# Altium schematic sheet Shield_sch.SchDoc
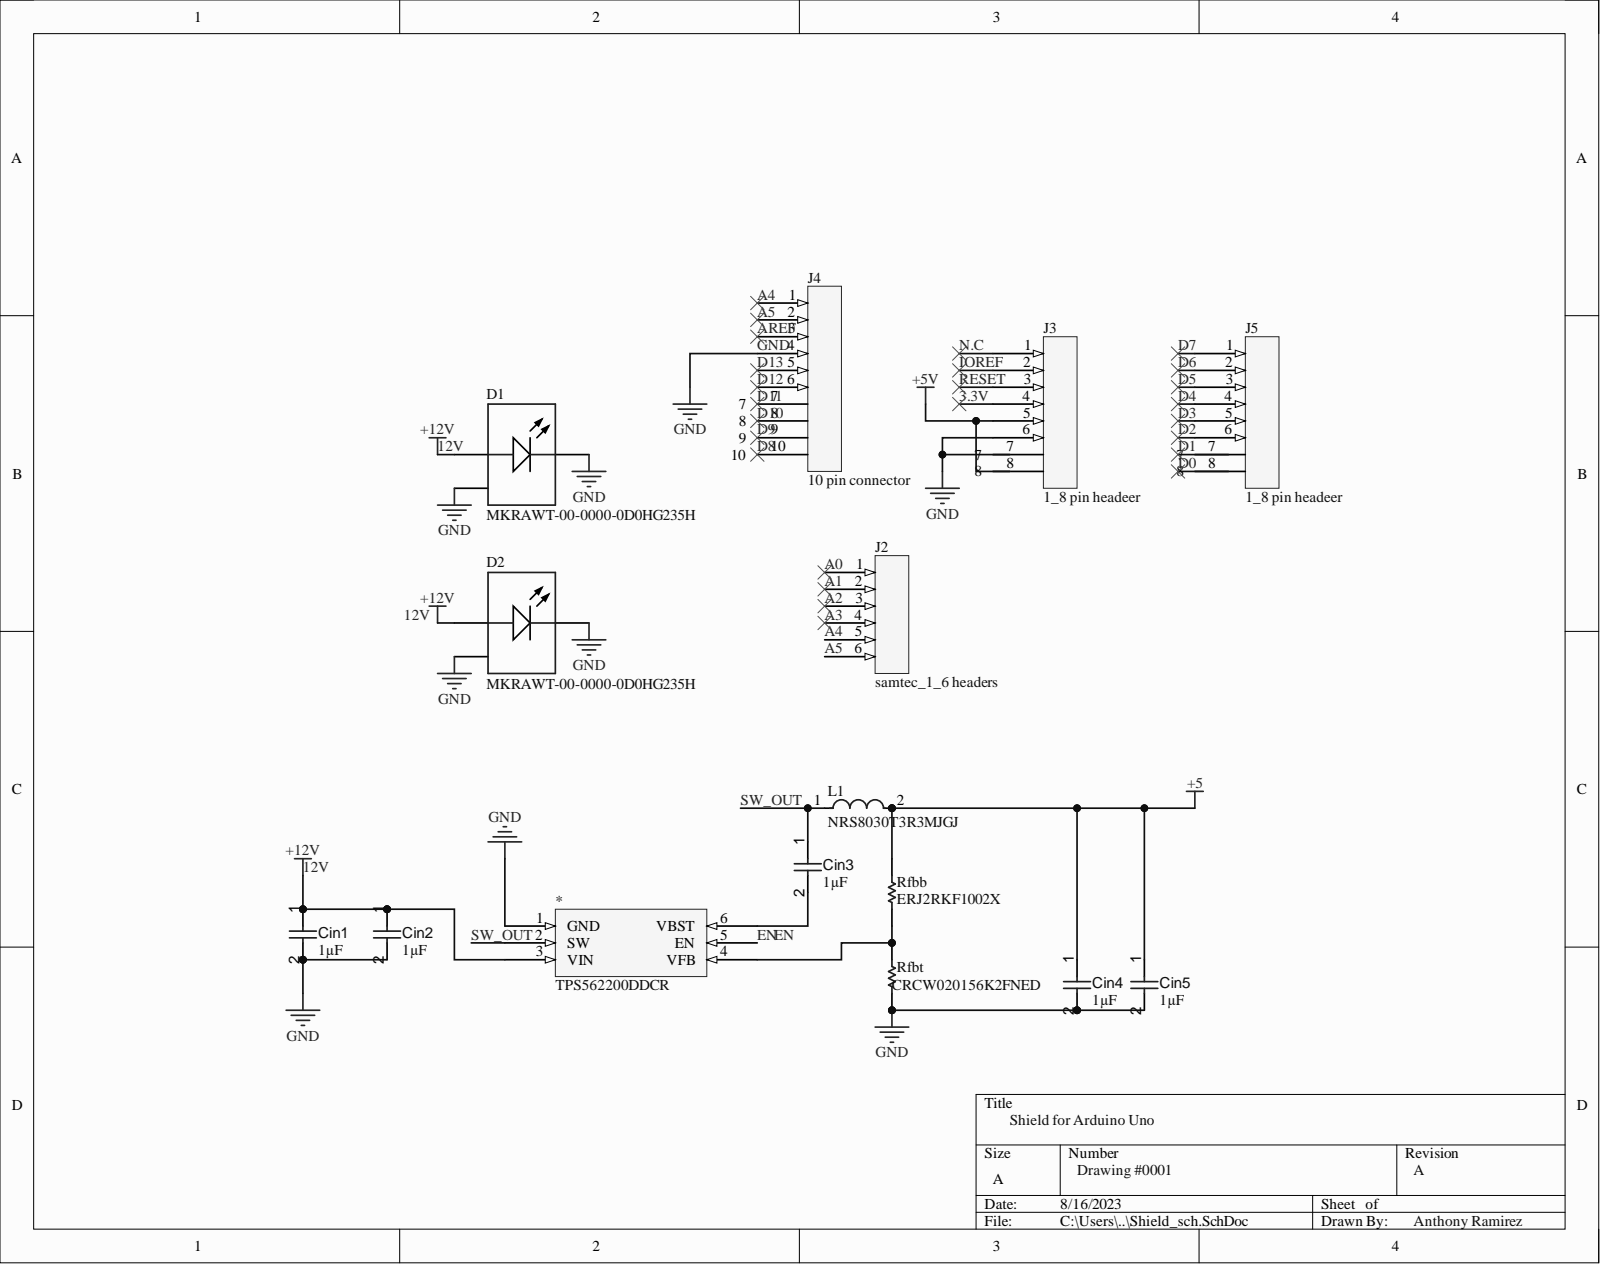
[diagram p0: Shield_sch.SchDoc]

## Parts
*  TPS562200DDCR  [SOT95P245X110-6N]
Cin1  value 1µF  [CAPC1608X90X40LL10T20]  (hidden comment: Capacitor 1 uF +/- 10% 25 V 0603)
Cin2  value 1µF  [CAPC1608X90X40LL10T20]  (hidden comment: Capacitor 1 uF +/- 10% 25 V 0603)
Cin3  value 1µF  [CAPC1608X90X40LL10T20]  (hidden comment: Capacitor 1 uF +/- 10% 25 V 0603)
Cin4  value 1µF  [CAPC1608X90X40LL10T20]  (hidden comment: Capacitor 1 uF +/- 10% 25 V 0603)
Cin5  value 1µF  [CAPC1608X90X40LL10T20]  (hidden comment: Capacitor 1 uF +/- 10% 25 V 0603)
D1  MKRAWT-00-0000-0D0HG235H  [CREE-MK-R-2_V]
D2  MKRAWT-00-0000-0D0HG235H  [CREE-MK-R-2_V]
J2  samtec_1_6 headers  [samtec_1_6 headers-Footprint-1]
J3  1_8 pin headeer  [1_8_Pin headers-Footprint-1]
J4  10 pin connector  [10 pin connector-Footprint-1]
J5  1_8 pin headeer  [1_8_Pin headers-Footprint-1]
L1  NRS8030T3R3MJGJ  [FP-NRS8030-MFG]
Rfbb  ERJ2RKF1002X  [RESC1005X40X25LL05T05]
Rfbt  CRCW020156K2FNED  [RESC0603X28X15NL05T05]

## Nets
+5: Cin4.1 Cin5.1 L1.2 Rfbb.1
+5V: J3.5
3.3V: J3.4
12V: *.3(VIN) Cin1.1 Cin2.1 D1.1 D2.1
A0: J2.1
A1: J2.2
A2: J2.3
A3: J2.4
A4: J2.5 J4.1
A5: J2.6 J4.2
AREF: J4.3
D2: J5.6
D3: J5.5
D4: J5.4
D5: J5.3
D6: J5.2
D7: J5.1
D12: J4.6
D13: J4.5
EN: *.5(EN)
GND: *.1(GND) Cin1.2 Cin2.2 Cin4.2 Cin5.2 D1.2 D1.3 D2.2 D2.3 J3.6 J4.4 Rfbt.2
IOREF: J3.2
N.C: J3.1
RESET: J3.3
SW_OUT: *.2(SW) Cin3.1 L1.1
Net*_4: *.4(VFB) Rfbb.2 Rfbt.1
Net*_6: *.6(VBST) Cin3.2
D0:
D1:
D8:
D9:
D10:
D11:

Not connected: J3 7 8; J4 7 8 9 10; J5 7 8

## Library data of the parts
* = CMP-019-00051-1: Switching Regulator, 2A, 650kHz Switching Freq-Max, PDSO6; Control Technique: PULSE WIDTH MODULATION; Max Input Voltage: 17V; Max Output Current: 4.3A; Min Input Voltage: 4.5V; Nominal Input Voltage: 12V; Number of Functions: 1; Package Body Material: Plastic; Switcher Configuration: BUCK; Analog IC - Other Type: SWITCHING REGULATOR; Component Kind: Standard; Height - Seated (Max): 1.1mm; JESD-30 Code: R-PDSO-G6; JESD-609 Code: e3; Length: 2.9mm; Max Operating Temperature: 85°C; Min Operating Temperature: -40°C; Moisture Sensitivity Level: 1; Number of Pins: 6; Package Code: TSSOP; Package Shape: Rectangular; Package Style: SMALL OUTLINE, THIN PROFILE, SHRINK PITCHMeter; Peak Reflow Temperature (Cel): 260°C; Reach SVHC Compliant: Yes; RoHS Compliant: Yes; Surface Mount: Yes; Switching Frequency-Max: 650kHz; Temperature Grade: INDUSTRIAL; Terminal Finish: Tin; Terminal Form: Gull Wing; Terminal Pitch: 0.95mm; Terminal Position: DUAL; Width: 1.6mm; Library Name: Anthony's_Test_Boards
Cin1-Cin5 = CMP-001-00005-6: Chip Capacitor, 1 uF, +/- 10%, 25 V, 0603 (1608 Metric); Capacitor Type: Ceramic; Voltage Rating: 25V; Case/Package: 0603; Component Kind: Standard; Max Operating Temperature: 125°C; Min Operating Temperature: -55°C; Mounting Technology: SM; Pins: 2; RoHS Compliant: True; Tolerance: 10%; Library Name: Anthony's_Test_Boards
D1 D2 = CMP-2100-00977-1: LED, White, 12 V, 2-Pin SMD, RoHS, Tape and Reel; Component Kind: Standard; Library Name: Altium Content Vault
J2 = CMP-002-00071-1: 1 row 6 pin headers with 1.27mm radius for arduino shield; Component Kind: Standard; Library Name: Anthony's_Test_Boards
J3 J5 = 1_8pin_headers-1: Board Connector, 8 Contact(s), 1 Row(s), Female, 0.049 inch Pitch, Crimp Terminal, Locking, Receptacle; Additional Feature: ROHS COMPLIANT; Board Mounting Option: PEG; Body Breadth: 0.126inch; Body Depth: 0.156inch; Body Length: 0.463inch; Contact Pattern: RECTANGULAR; Contact Resistance: 20mR; Contact Style: SQ PIN-SKT; Dielectric Withstanding Voltage (AC): 1.5kV; DIN Conformance: No; Durability: 25Cycles; Insulation Resistance: 100MR; Mating Contact Row Spacing: 0.118inch; Mating Information: MULTIPLE MATING PARTS AVAILABLE; Number Of Connectors: 1; Number of Contacts: 8; Number Of PCB Rows: 2; Option: General Purpose; PCB Contact Row Spacing: 4.6228mm; Polarization Key: POLARIZED HOUSING; Rated Current (Signal): 5A; Reference Standard: UL; Reliability: COMMERCIAL; Body/Shell Style: RECEPTACLE; Component Kind: Standard; Connector Type: BOARD CONNECTOR; Contact Finish - Mating: NOT SPECIFIED; Contact Finish - Termination: Tin (Sn); Contact Gender: Female; Contact Material: Copper Alloy; Filter Feature: No; Flammability Rating: UL94 V-0; IEC Conformance: No; Insulation Color: Black; Insulation Material: Polyester; JESD-609 Code: e3; Mating Contact Pitch: 0.049inch; Max Operating Temperature: 85°C; MIL Conformance: No; Min Operating Temperature: -40°C; Mixed Contacts: No; Mount: Board; Mounting Option 1: LOCKING; Mounting Style: STRAIGHT; Number of Rows Loaded: 1; PCB Contact Pattern: RECTANGULAR; Reach SVHC Compliant: Yes; RoHS Compliant: Yes; Terminal Pitch: 1.25mm; Termination: Crimp; Library Name: Anthony's_Test_Boards
J4 = CMP-002-00074-1: 10pin connector; Connector Type: BOARD CONNECTOR; Component Kind: Standard; Max Operating Temperature: 85°C; Min Operating Temperature: -40°C; RoHS Compliant: Yes; Simulation: 10 pin connector-Simulation-1; Library Name: Anthony's_Test_Boards
L1 = CMP-14484-000405-1: Inductor Power Shielded Wirewound 3.3uH 20% 100KHz Ferrite 4.2A 24.7mΩ DCR T/R; Current Rating: 4.3A; DC Resistance (DCR): 19mR; Self Resonant Frequency: 50MHz; Case/Package: Nonstandard; Component Kind: Standard; Core Material: Ferrite; Depth: 8.2mm; Halogen Free: Halogen Free; Height: 3mm; Height - Seated (Max): 0.118inch; Inductance: 3.3uH; Inductance Tolerance: 20%; Lead Free: Lead Free; Lead Pitch: 5.6mm; Length: 8mm; Library Name: Altium Content Vault; Max DC Current: 4.2A; Max Operating Temperature: 125°C; Min Operating Temperature: -25°C; Mount: Surface Mount; Number of Pins: 2; Package Quantity: 1000; Packaging: Tape and Reel; Radiation Hardening: No; REACH SVHC: No SVHC; Resistor Type: Wirewound; RMS Current (Irms): 4.3A; RoHS Compliant: Yes; Saturation Current: 4.2A; Series: NRS; Shielding: Shielded; Termination: SMD/SMT; Test Frequency: 100kHz; Tolerance: 20%; Width: 0.315inch
Rfbb = CMP-2000-07588-1: Chip Resistor, 10 KOhm, +/- 1%, 0.1 W, -55 to 155 degC, 0402 (1005 Metric), RoHS, Tape and Reel; Manufacturer: Panasonic; Case\/Package: 0402; Max Power Dissipation: 100mW; Resistance: 10KOhm; Temperature Coefficient: 100ppm\/degreesC; Voltage Rating: 50V; Case Code (Imperial): 0402; Case Code (Metric): 1005; Component Kind: Standard; Composition: ThickFilm; Contact Plating: Tin; Datasheet URL: https://industrial.panasonic.com/cdbs/www-data/pdf/RDA0000/AOA0000C304.pdf; Datasheet Version: 02/2017; Depth: 0.5mm; Height: 0.35mm; Lead Free: LeadFree; Length: 1mm; Library Name: Altium Content Vault; Manufacturer URL: http://www.panasonic.com/; Max Operating Temperature: 155degC; Military Standard: Not; Min Operating Temperature: -55degC; Mount: SurfaceMount; Mounting Technology: SurfaceMount; Number of Pins: 2; Number of Terminations: 2; Package Description: 2-Pin Surface Mount Device, Body 1 x 0.5 mm; Package Quantity: 1; Package Reference: 402; Packaging: TapeandReel; Power Rating: 100mW; Radiation Hardening: No; REACH SVHC: NoSVHC; RoHSCompliant: True; Termination: SMD/SMT; Tolerance: 1%; Voltage Rating (DC): 50V; Width: 0.02inch
Rfbt = CMP-2004-00723-1: Manufacturer: Vishay Dale; Component Kind: Standard; Library Name: Altium Content Vault; Part Number: CRCW020156K2FNED
